# Altium schematic sheet OPENSDA.SchDoc
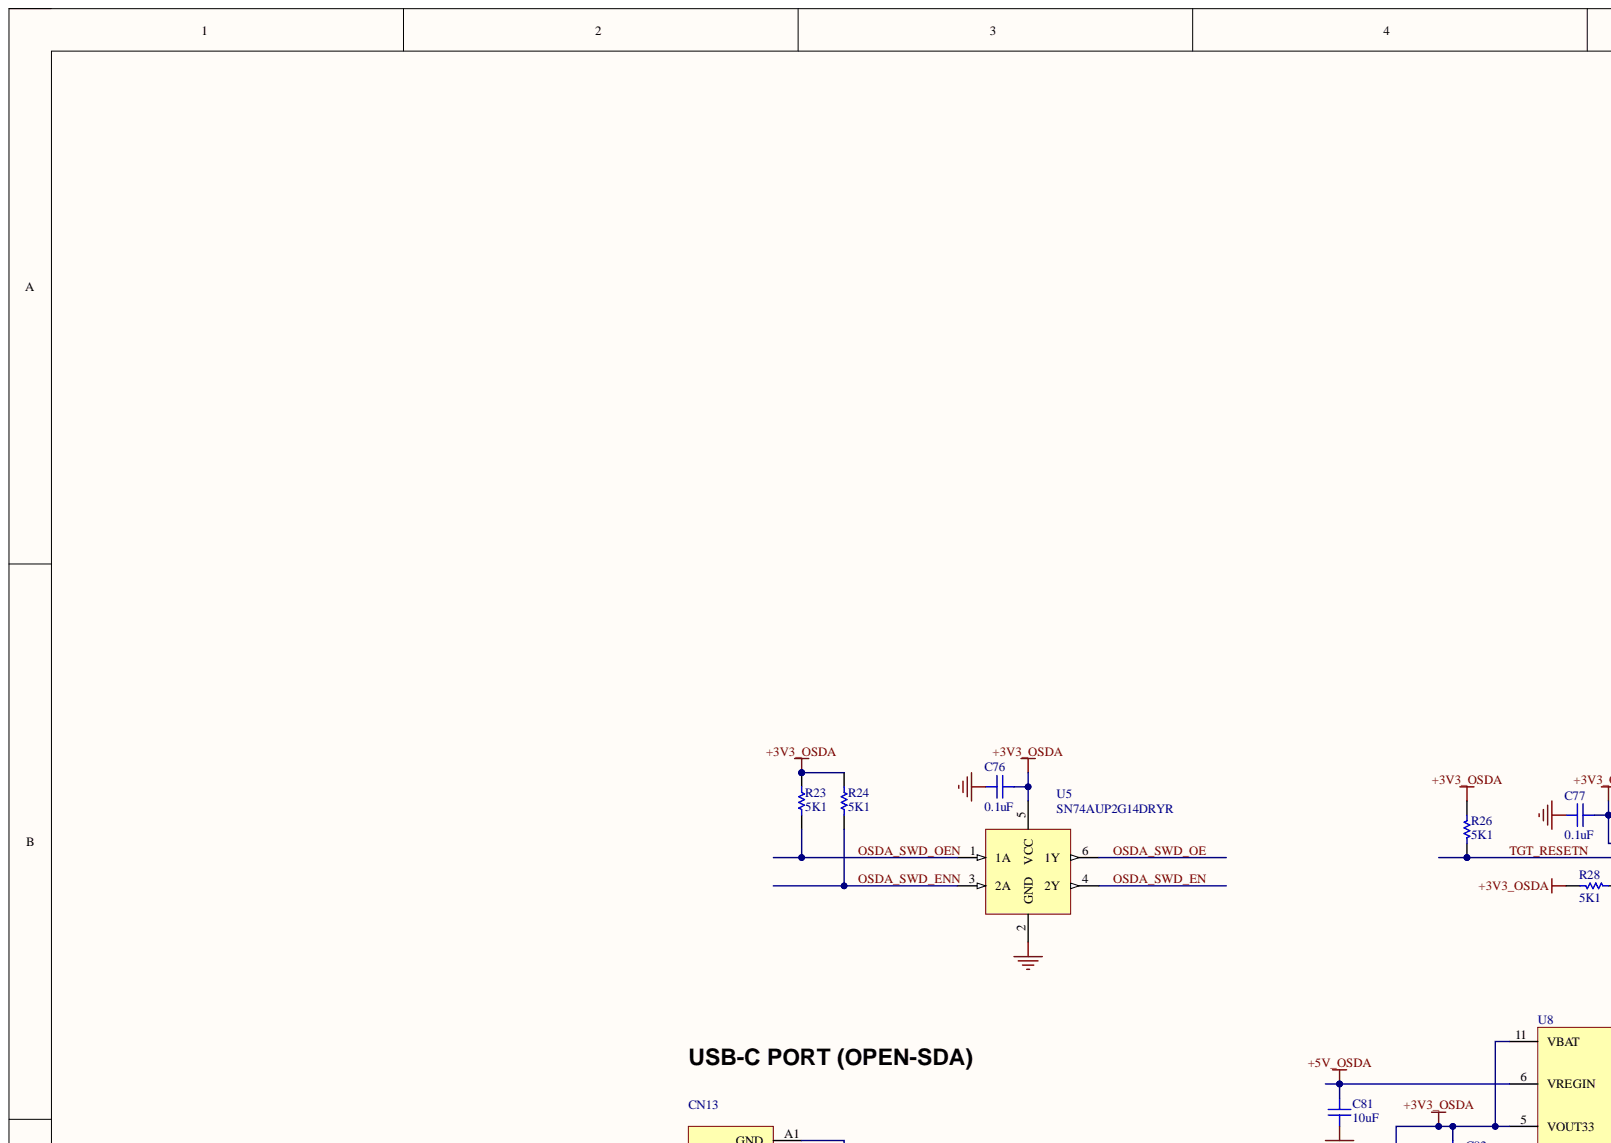
[diagram p0: OPENSDA.SchDoc, top left]
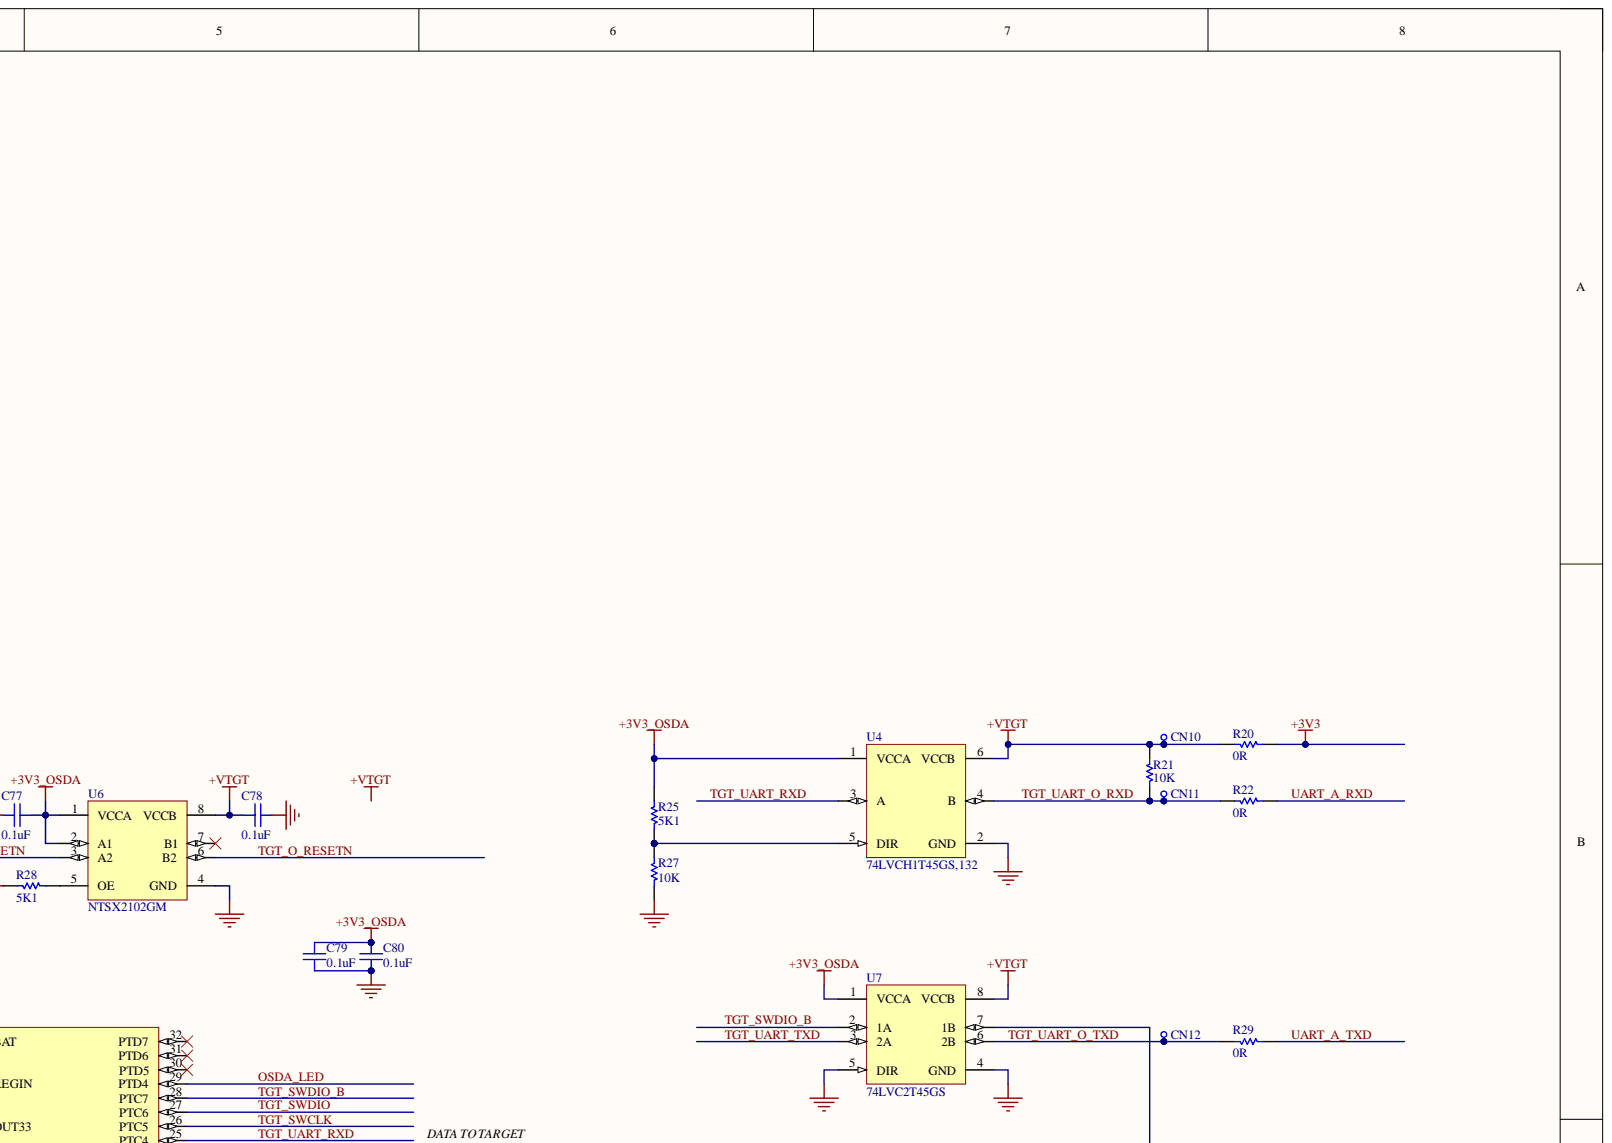
[diagram p1: OPENSDA.SchDoc, top right]
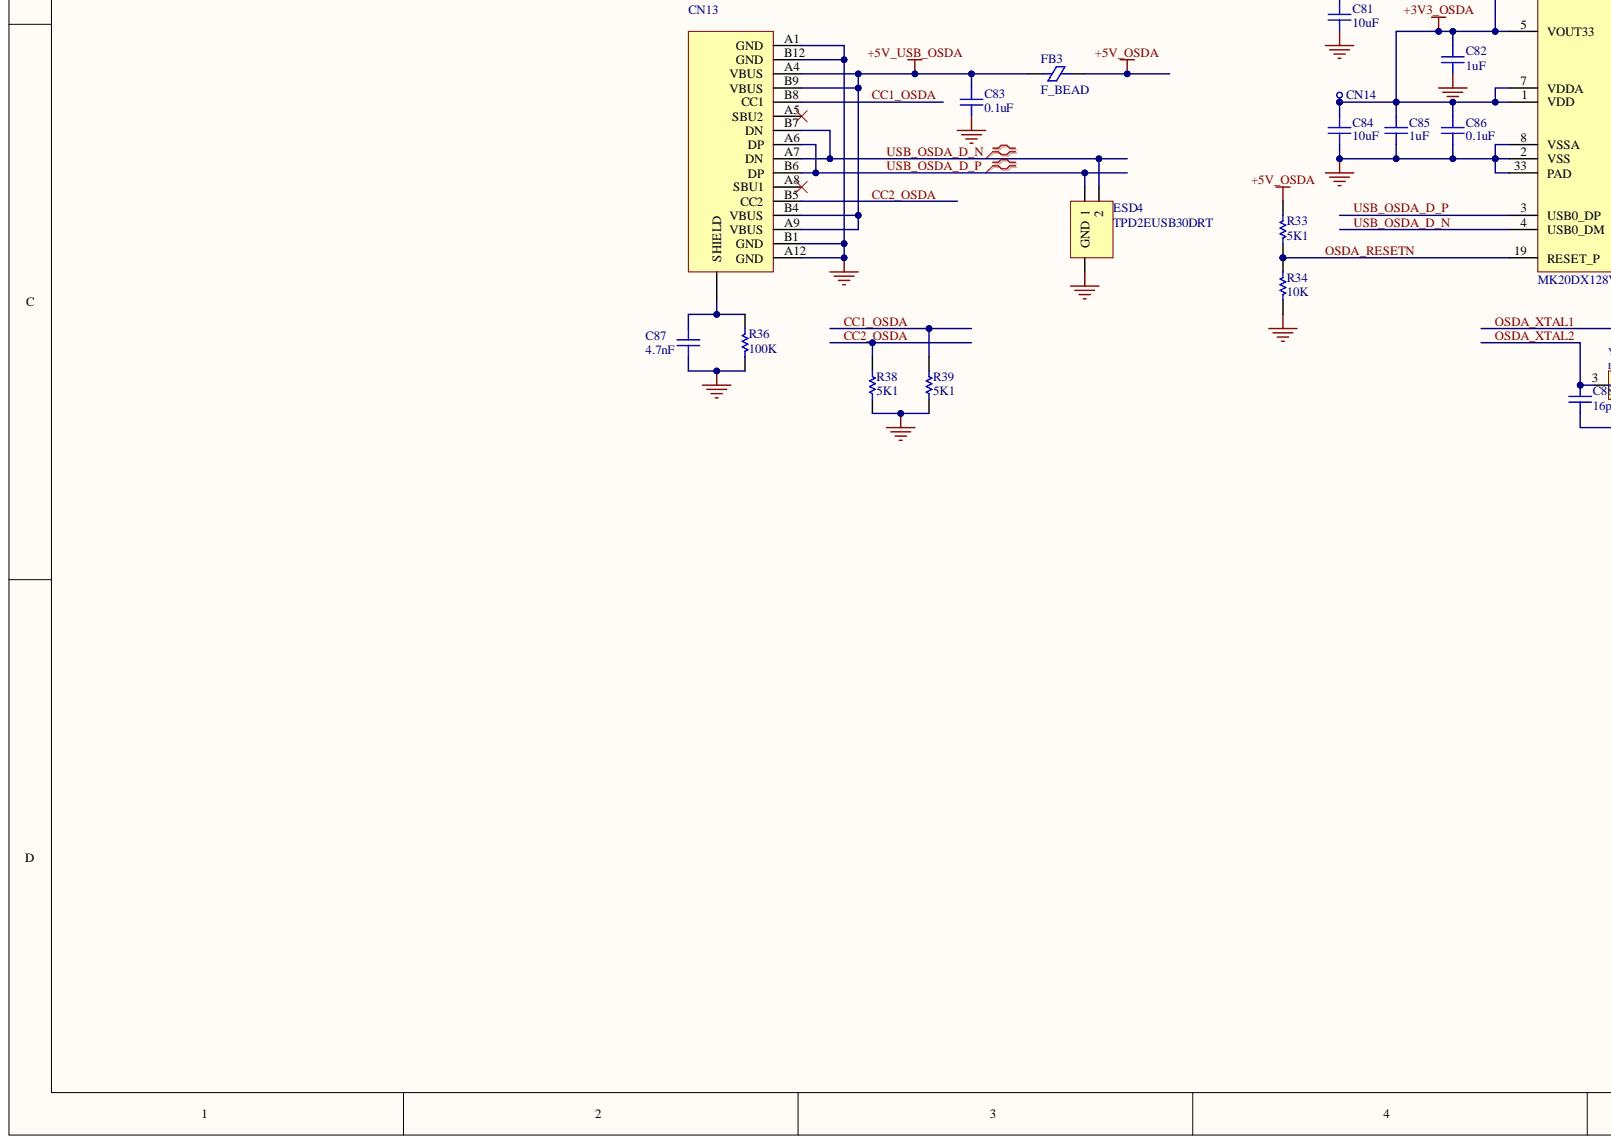
[diagram p2: OPENSDA.SchDoc, bottom left]
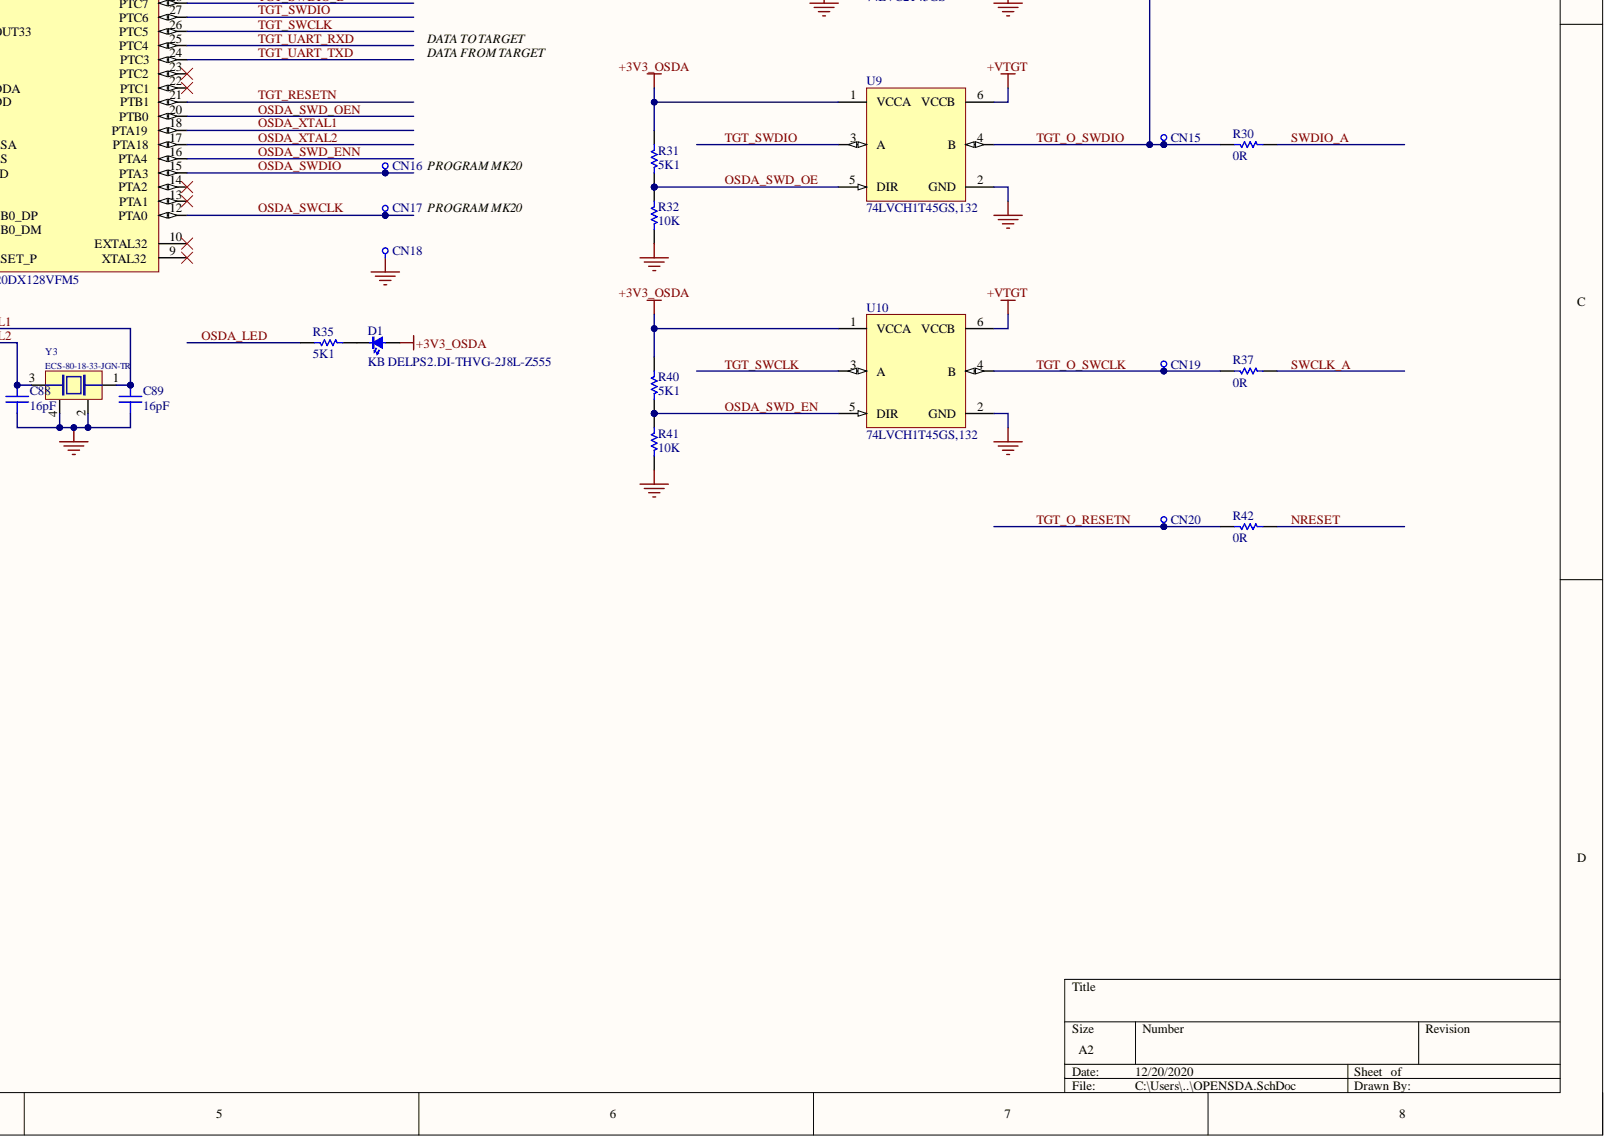
[diagram p3: OPENSDA.SchDoc, bottom right]

## Parts
C76  0.1uF  [AA-0402]
C77  0.1uF  [AA-0402]
C78  0.1uF  [AA-0402]
C79  0.1uF  [AA-0402]
C80  0.1uF  [AA-0402]
C81  10uF  [AA-0402]
C82  1uF  [AA-0402]
C83  0.1uF  [AA-0402]
C84  10uF  [AA-0402]
C85  1uF  [AA-0402]
C86  0.1uF  [AA-0402]
C87  4.7nF  [AA-0402]
C88  16pF  [AA-0402]
C89  16pF  [AA-0402]
CN10  TEST POINT  [TESTPAD-1MM]  (value not drawn)  (no BOM)
CN11  TEST POINT  [TESTPAD-1MM]  (value not drawn)  (no BOM)
CN12  TEST POINT  [TESTPAD-1MM]  (value not drawn)  (no BOM)
CN13  (no value)  [U262-16XN-4BVC11]
CN14  TEST POINT  [TESTPAD-1MM]  (value not drawn)  (no BOM)
CN15  TEST POINT  [TESTPAD-1MM]  (value not drawn)  (no BOM)
CN16  TEST POINT  [TESTPAD-1MM]  (value not drawn)  (no BOM)
CN17  TEST POINT  [TESTPAD-1MM]  (value not drawn)  (no BOM)
CN18  TEST POINT  [TESTPAD-1MM]  (value not drawn)  (no BOM)
CN19  TEST POINT  [TESTPAD-1MM]  (value not drawn)  (no BOM)
CN20  TEST POINT  [TESTPAD-1MM]  (value not drawn)  (no BOM)
D1  KB DELPS2.DI-THVG-2J8L-Z555  [KT DELQS1.12-LED]
ESD4  TPD2EUSB30DRT  [SOT-3]
FB3  F_BEAD  [AA-0603]
R20  0R  [AA-0402]
R21  10K  [AA-0402]
R22  0R  [AA-0402]
R23  5K1  [AA-0402]
R24  5K1  [AA-0402]
R25  5K1  [AA-0402]
R26  5K1  [AA-0402]
R27  10K  [AA-0402]
R28  5K1  [AA-0402]
R29  0R  [AA-0402]
R30  0R  [AA-0402]
R31  5K1  [AA-0402]
R32  10K  [AA-0402]
R33  5K1  [AA-0402]
R34  10K  [AA-0402]
R35  5K1  [AA-0402]
R36  100K  [AA-0402]
R37  0R  [AA-0402]
R38  5K1  [AA-0402]
R39  5K1  [AA-0402]
R40  5K1  [AA-0402]
R41  10K  [AA-0402]
R42  0R  [AA-0402]
U4  74LVCH1T45GS,132  [SOT1202-NXP-XSON-6]
U5  SN74AUP2G14DRYR  [AA-DRY6]
U6  NTSX2102GM  [SOT902-2-XQFN8]
U7  74LVC2T45GS  [SOT1203-NXP-XSON-8]
U8  MK20DX128VFM5  [QFN32-FREESCALE-NXP]
U9  74LVCH1T45GS,132  [SOT1202-NXP-XSON-6]
U10  74LVCH1T45GS,132  [SOT1202-NXP-XSON-6]
Y3  ECS-80-18-33-JGN-TR  [TSX_3.2X2.5]

## Nets
+3V3: R20.2
+3V3_OSDA: C76.2 C77.2 C79.1 C80.1 C82.1 C84.1 C85.1 C86.1 CN14.TST D1.1 R23.2 R24.2 R25.1 R26.2 R28.1 R31.1 R40.1 U4.1(VCCA) U5.5(VCC) U6.1(VCCA) U6.2(A1) U7.1(VCCA) U8.1(VDD) U8.5(VOUT33) U8.7(VDDA) U8.11(VBAT) U9.1(VCCA) U10.1(VCCA)
+5V_OSDA: C81.1 FB3.2 R33.1 U8.6(VREGIN)
+5V_USB_OSDA: C83.1 CN13.A4(VBUS) CN13.A9(VBUS) CN13.B4(VBUS) CN13.B9(VBUS) FB3.1
+VTGT: C78.2 CN10.TST R20.1 R21.1 U4.6(VCCB) U6.8(VCCB) U7.8(VCCB) U9.6(VCCB) U10.6(VCCB)
GND: C76.1 C77.1 C78.1 C79.2 C80.2 C81.2 C82.2 C83.2 C84.2 C85.2 C86.2 C87.1 C88.2 C89.2 CN13.A1(GND) CN13.A12(GND) CN13.B1(GND) CN13.B12(GND) CN18.TST ESD4.3 R27.2 R32.2 R34.2 R36.2 R38.1 R39.1 R41.2 U4.2(GND) U5.2(GND) U6.4(GND) U7.4(GND) U7.5(DIR) U8.2(VSS) U8.8(VSSA) U8.33(PAD) U9.2(GND) U10.2(GND) Y3.2 Y3.4
CC1_OSDA: CN13.B8(CC1) R39.2
CC2_OSDA: CN13.B5(CC2) R38.2
NRESET: R42.2
OSDA_LED: R35.1 U8.29(PTD4)
OSDA_RESETN: R33.2 R34.1 U8.19(RESET_P)
OSDA_SWCLK: CN17.TST U8.12(PTA0)
OSDA_SWD_EN: R40.2 R41.1 U5.4(2Y) U10.5(DIR)
OSDA_SWD_ENN: R24.1 U5.3(2A) U8.16(PTA4)
OSDA_SWD_OE: R31.2 R32.1 U5.6(1Y) U9.5(DIR)
OSDA_SWD_OEN: R23.1 U5.1(1A) U8.20(PTB0)
OSDA_SWDIO: CN16.TST U8.15(PTA3)
OSDA_XTAL1: C89.1 U8.18(PTA19) Y3.1
OSDA_XTAL2: C88.1 U8.17(PTA18) Y3.3
SWCLK_A: R37.2
SWDIO_A: R30.2
TGT_O_RESETN: CN20.TST R42.1 U6.6(B2)
TGT_O_SWCLK: CN19.TST R37.1 U10.4(B)
TGT_O_SWDIO: CN15.TST R30.1 U7.7(1B) U9.4(B)
TGT_RESETN: R26.1 U6.3(A2) U8.21(PTB1)
TGT_SWCLK: U8.26(PTC5) U10.3(A)
TGT_SWDIO: U8.27(PTC6) U9.3(A)
TGT_SWDIO_B: U7.2(1A) U8.28(PTC7)
TGT_UART_O_RXD: CN11.TST R21.2 R22.1 U4.4(B)
TGT_UART_O_TXD: CN12.TST R29.1 U7.6(2B)
TGT_UART_RXD: U4.3(A) U8.25(PTC4)
TGT_UART_TXD: U7.3(2A) U8.24(PTC3)
UART_A_RXD: R22.2
UART_A_TXD: R29.2
USB_OSDA_D_N: CN13.A7(DN) CN13.B7(DN) ESD4.2 U8.4(USB0_DM)
USB_OSDA_D_P: CN13.A6(DP) CN13.B6(DP) ESD4.1 U8.3(USB0_DP)
NetC87_2: C87.2 CN13.SHIELD R36.1
NetD1_2: D1.2 R35.2
NetR25_2: R25.2 R27.1 U4.5(DIR)
NetR28_2: R28.2 U6.5(OE)
DATA FROM TARGET:
DATA TO TARGET:
PROGRAM MK20:

Not connected: CN13 A5(SBU2) A8(SBU1); U6 7(B1); U8 9(XTAL32) 10(EXTAL32) 13(PTA1) 14(PTA2) 22(PTC1) 23(PTC2) 30(PTD5) 31(PTD6) 32(PTD7)

## Library data of the parts
C76-C80 C83 C86 = 00005500W: 100nF 16V 10% X7R 0402  -55+125; Database Table Name: Capacitor; Component Kind: Standard; Library Name: ALT_DB.DBLIB; Value: 0.1uF
C81 C82 C84 C85 C88 C89 = 00005500W: 100nF 16V 10% X7R 0402  -55+125; Database Table Name: Capacitor; Value: 0.1uF; Component Kind: Standard; Library Name: ALT_DB.DBLIB
C87 = 00005465: 4.7nF 25V +-10% X7R  0402  -55+125; Database Table Name: Capacitor; Component Kind: Standard; Library Name: ALT_DB.DBLIB
CN10-CN12 CN14-CN20 = TESTPOINT: Library Name: ULTIMA_LIB.SCHLIB
CN13 = 72300341D: USB - C USB 3.1, Superspeed+ Receptacle Connector 16 Position SMT, RA;max3A;-30+85; Application_BuildNumber: 21.0.8.223; Database Table Name: Connectors; Component Kind: Standard; Library Name: ALT_DB.DBLIB
D1 = LED: Manufacturer: OSRAM Opto Semiconductors; Component Kind: Standard; Library Name: ULTIMA_LIB.SCHLIB
R20-R22 R27 R29 R30 R32 R34 R37 R41 R42 = 10012076Wa: Database Table Name: Resistor; Component Kind: Standard; Library Name: ALT_DB.DBLIB; Signal Integrity: Res; Simulation: RESISTOR
  R21 R27 R32 R34 R41: Value: 10K
R23-R26 R28 R31 R33 R35 R38-R40 = 10012076Wa: 5K1 1% 0402 1/16W 100ppm RC0402FR-5K1L; Database Table Name: Resistor; Component Kind: Standard; Library Name: ALT_DB.DBLIB; Signal Integrity: Res; Simulation: RESISTOR; Value: 5K1
R36 = 10012142: 100K 1% 0402 1/16W 100ppm RC0402FR-100KL; Database Table Name: Resistor; Component Kind: Standard; Library Name: ALT_DB.DBLIB
U6 = NTSX2102GU8H: Component Kind: Standard; Library Name: ULTIMA_LIB.SCHLIB
Y3 = (no symbol name): 12.00000 MHz Ultra Miniatur Quartz crystal,Cl=8pF ,2.5x2.0x0.45mm,+-30ppm(+25C) ;-30+85; Manufacturer: EPSON; Manufacturer Part Number: FA-20H 12.0000MB30Z-W; Part Number: 25000266G; Allegro PCB Footprint: CX2520SB; COMPHEIGHT: 0.45; Gaminys: IGN; Komentaras: $; Value: 12.00000 MHz+-30ppm; ActivepartsID: https://support.epson.biz/td/api/doc_check.php?dl=brief_FA-20H_en.pdf; Component Kind: Standard; Data: 26/03/2018; Distributor: Digi-Key; Distributor Part Number: FA-20H12.0000MB30Z-W-ND; Part Type: Quartz crystal\SMD 2.5x2.0; Price: 0.42; SMD: Yes; Datasheet: E:\pdf\osciliatoriai\FA-20H_en.pdf; Library Name: ULTIMA_LIB.SCHLIB
ESD4 = TPD2EUSB30DRT: Component Kind: Standard; Library Name: ULTIMA_LIB.SCHLIB
FB3 = F_BEAD: Component Kind: Standard; Simulation: L_0402; Library Name: ULTIMA_LIB.SCHLIB
U4 U9 U10 = 74LVCH1T45GS,132: Component Kind: Standard; Library Name: ULTIMA_LIB.SCHLIB
U5 = SN74AUP2G14DRYR: Component Kind: Standard; Library Name: ULTIMA_LIB.SCHLIB
U7 = 74LVC2T45GS: Component Kind: Standard; Library Name: ULTIMA_LIB.SCHLIB
U8 = MK20DX128VFM5: Component Kind: Standard; Library Name: ULTIMA_LIB.SCHLIB
